# Altium schematic sheet Ukl_sterowania.SchDoc
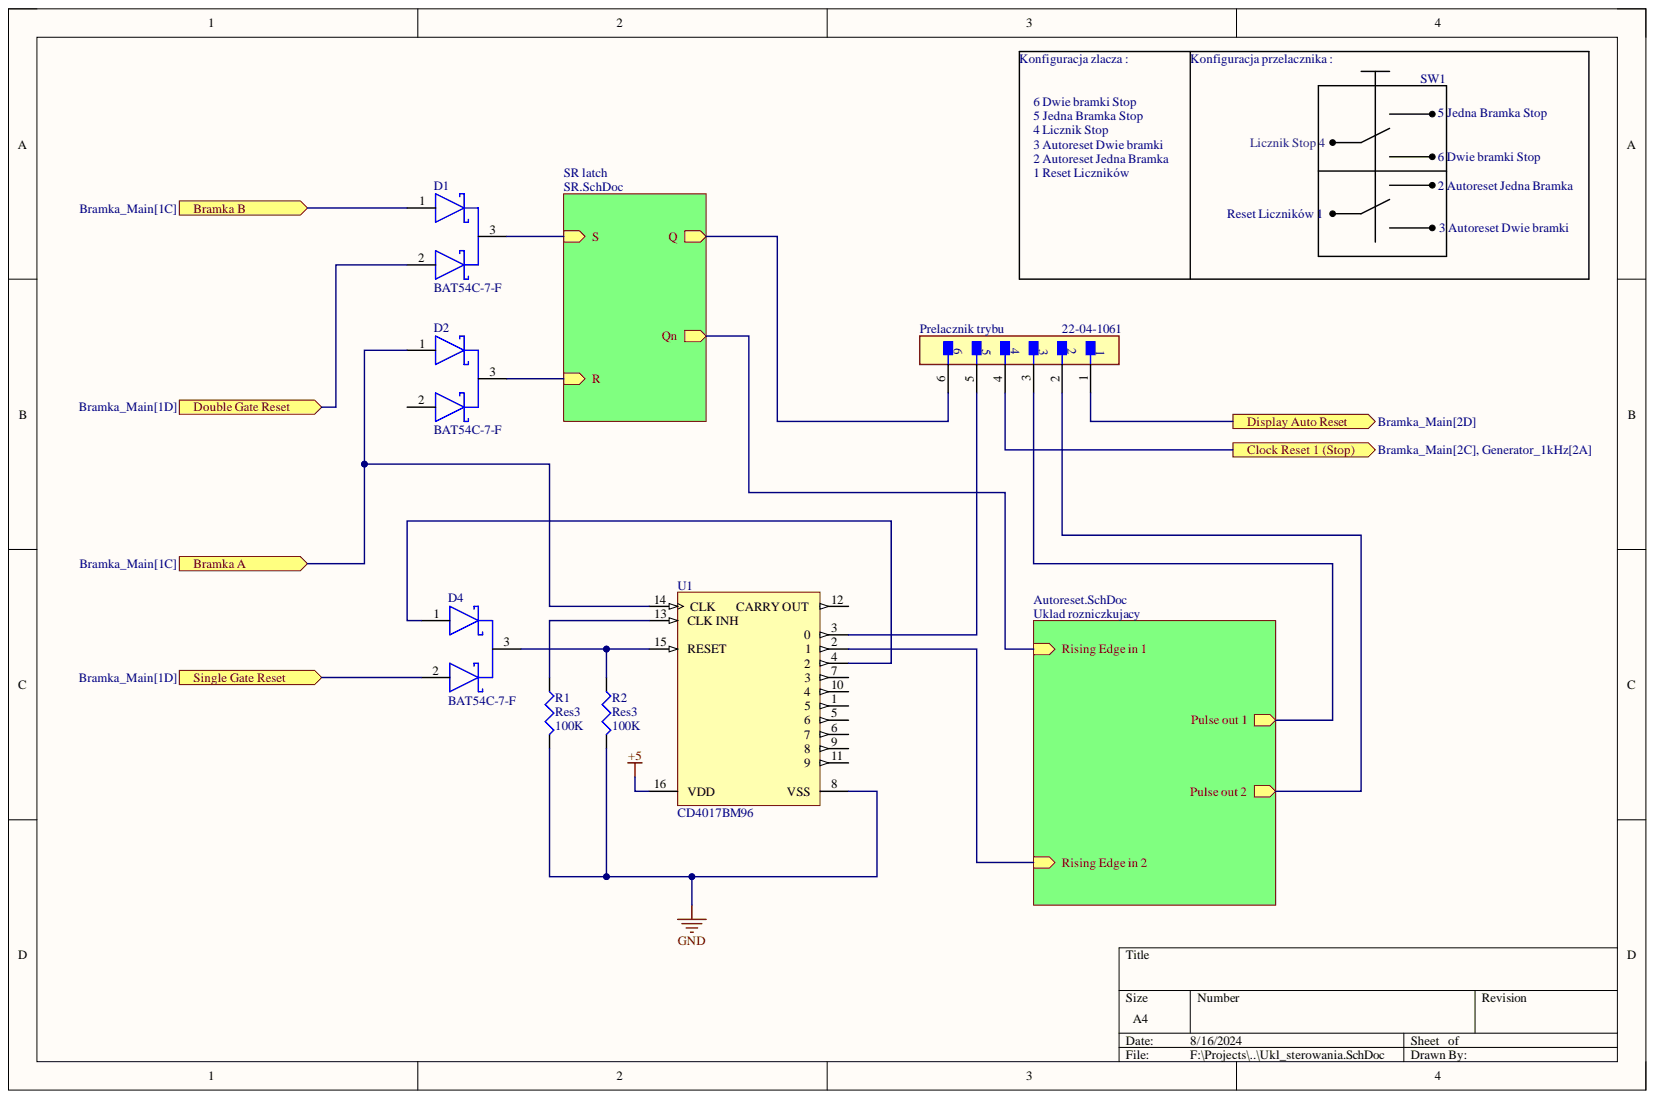
[diagram p0: Ukl_sterowania.SchDoc]

## Parts
D1  BAT54C-7-F  [FP-SOT23-IPC_B]
D2  BAT54C-7-F  [FP-SOT23-IPC_B]
D4  BAT54C-7-F  [FP-SOT23-IPC_B]
Prelacznik_trybu  22-04-1061  [FP-22-04-1061-MFG]
R1  Res3  value 100K  [J1-0603]
R2  Res3  value 100K  [J1-0603]
U1  CD4017BM96  [D0016A_L]

## Ports
Bramka A, Bramka B, Clock Reset 1 (Stop), Display Auto Reset, Double Gate Reset, Single Gate Reset

## Nets
+5: U1.16(VDD)
GND: R1.2 R2.2 U1.8(VSS)
Bramka A: D2.1 U1.14(CLK) ; port Bramka A
Bramka B: D1.1 ; port Bramka B
Clock Reset 1 (Stop): Prelacznik_trybu.4 ; port Clock Reset 1 (Stop)
Display Auto Reset: Prelacznik_trybu.1 ; port Display Auto Reset
Double Gate Reset: D1.2 ; port Double Gate Reset
Single Gate Reset: D4.2 ; port Single Gate Reset
NetD1_3: D1.3
NetD2_2: D2.2
NetD2_3: D2.3
NetD4_1: D4.1 U1.4
NetD4_3: D4.3 R2.1 U1.15(RESET)
NetPrelacznik trybu_2: Prelacznik_trybu.2
NetPrelacznik trybu_3: Prelacznik_trybu.3
NetPrelacznik trybu_5: Prelacznik_trybu.5 U1.3
NetPrelacznik trybu_6: Prelacznik_trybu.6
NetR1_1: R1.1 U1.13(CLK_INH)
NetU1_1: U1.1
NetU1_2: U1.2
NetU1_5: U1.5
NetU1_6: U1.6
NetU1_7: U1.7
NetU1_9: U1.9
NetU1_10: U1.10
NetU1_11: U1.11
NetU1_12: U1.12(CARRY_OUT)
Qn:

## Library data of the parts
D1 D2 D4 = CMP-12790-000084-1: DIODE ARRAY SCHOTTKY 30V SOT23-3; Average Rectified Current: 200mA; Capacitance: 10pF; Element Configuration: Common Cathode; Forward Voltage: 800mV; Max Forward Surge Current (Ifsm): 600mA; Max Power Dissipation: 200mW; Max Reverse Current: 2uA; Reverse Recovery Time: 5ns; Case/Package: SOT-23; Component Kind: Standard; Contact Plating: Tin; Current Rating: 200mA; Forward Current: 200mA; Height: 1mm; Lead Free: Lead Free; Length: 2.9mm; Library Name: Altium Content Vault; Max Operating Temperature: 150°C; Max Repetitive Reverse Voltage (Vrrm): 30V; Max Reverse Voltage (DC): 30V; Min Operating Temperature: -65°C; Mount: Surface Mount; Number of Pins: 3; Package Quantity: 3000; Packaging: Tape and Reel; Peak Non-Repetitive Surge Current: 600mA; Power Dissipation: 200mW; Power Rating: 200mW; Radiation Hardening: No; REACH SVHC: No SVHC; RoHS Compliant: Yes; Series: -; Termination: SMD/SMT; Voltage Rating (DC): 30V; Weight: 0.000282oz; Width: 1.3mm
Prelacznik_trybu = CMP-04776-000934-1: CONN HEADER VERT 6POS 2.5MM; Connector Type: Male Pin; Contact Resistance: 20mR; Current Rating: 3A; Gender: Male; Glow Wire Compliant: Non-compliant; Hole Diameter: 1.19mm; Insulation Resistance: 1GR; Max Voltage Rating (DC): 250.25kV; Number of Circuits: 6; Voltage Rating: 250V; Color: Natural; Component Kind: Standard; Contact Gender: Male; Contact Material: Brass; Contact Mating Length: 0.295inch; Contact Plating: Tin; Contact Type: Male Pin; Fastening Type: Friction; Flammability Rating: UL94 V-0; Halogen Free: Low Halogen; Height: 11.7mm; Housing Color: Natural; Housing Material: Nylon; Lead Free: Lead Free; Lead Pitch: 2.5mm; Length: 14.5mm; Library Name: Altium Content Vault; Max Operating Temperature: 105°C; Min Operating Temperature: -40°C; Mount: Through Hole; Number of Contacts: 6; Number of Poles: 6; Number of Positions: 6; Number of Rows: 1; Orientation: Straight; Package Quantity: 1; Packaging: Bulk; Pitch: 2.5mm; Radiation Hardening: No; Series: KK® 5045; Termination: Solder; Voltage Rating (AC): 250V; Voltage Rating (DC): 250V; Width: 5.8mm; Working Voltage: 250V
R1 R2 = Res3: Resistor; Component Kind: Standard; LatestRevisionDate: 29-May-2009; LatestRevisionNote: IPC-7351 Footprint Added.; Library Name: Miscellaneous Devices.IntLib; PackageDescription: Chip Resistor; PackageReference: J1-0603; Published: 8-Jun-2000; Signal Integrity: Res; Simulation: RESISTOR; Publisher: Altium Limited
U1 = CMP-1623-00072-2: CMOS Decade Counter with 10 Decoded Outputs, D0016A, LARGE T&R; Manufacturer: Texas Instruments; Bits(#): 10; F @ Nom Voltage(Max)(Mhz): 8; ICC @ Nom Voltage(Max)(mA): 0.03; Inputs: 1; Output Drive (IOL/IOH)(Max)(mA): 1.5/-1.5; Rating: Military; Schmitt Trigger: No; Technology Family: CD4000; tpd @ Nom Voltage(Max)(ns): 270; VCC(Max)(V): 18; VCC(Min)(V): 3; Code_JEDEC: MS-012 variation AC; Component Kind: Standard; DatasheetVersion: SCHS027C; Function: Counter; Generic Part Number: CD4017B; Input Type: CMOS; Library Name: Altium Content Vault; Operating Temperature Range(C): -55 to 125; Output Type: CMOS; PackageReference: D0016A; Type: Decade; Voltage(Nom)(V): 10
